# Altium schematic sheet Nixie Valve Clock.SchDoc
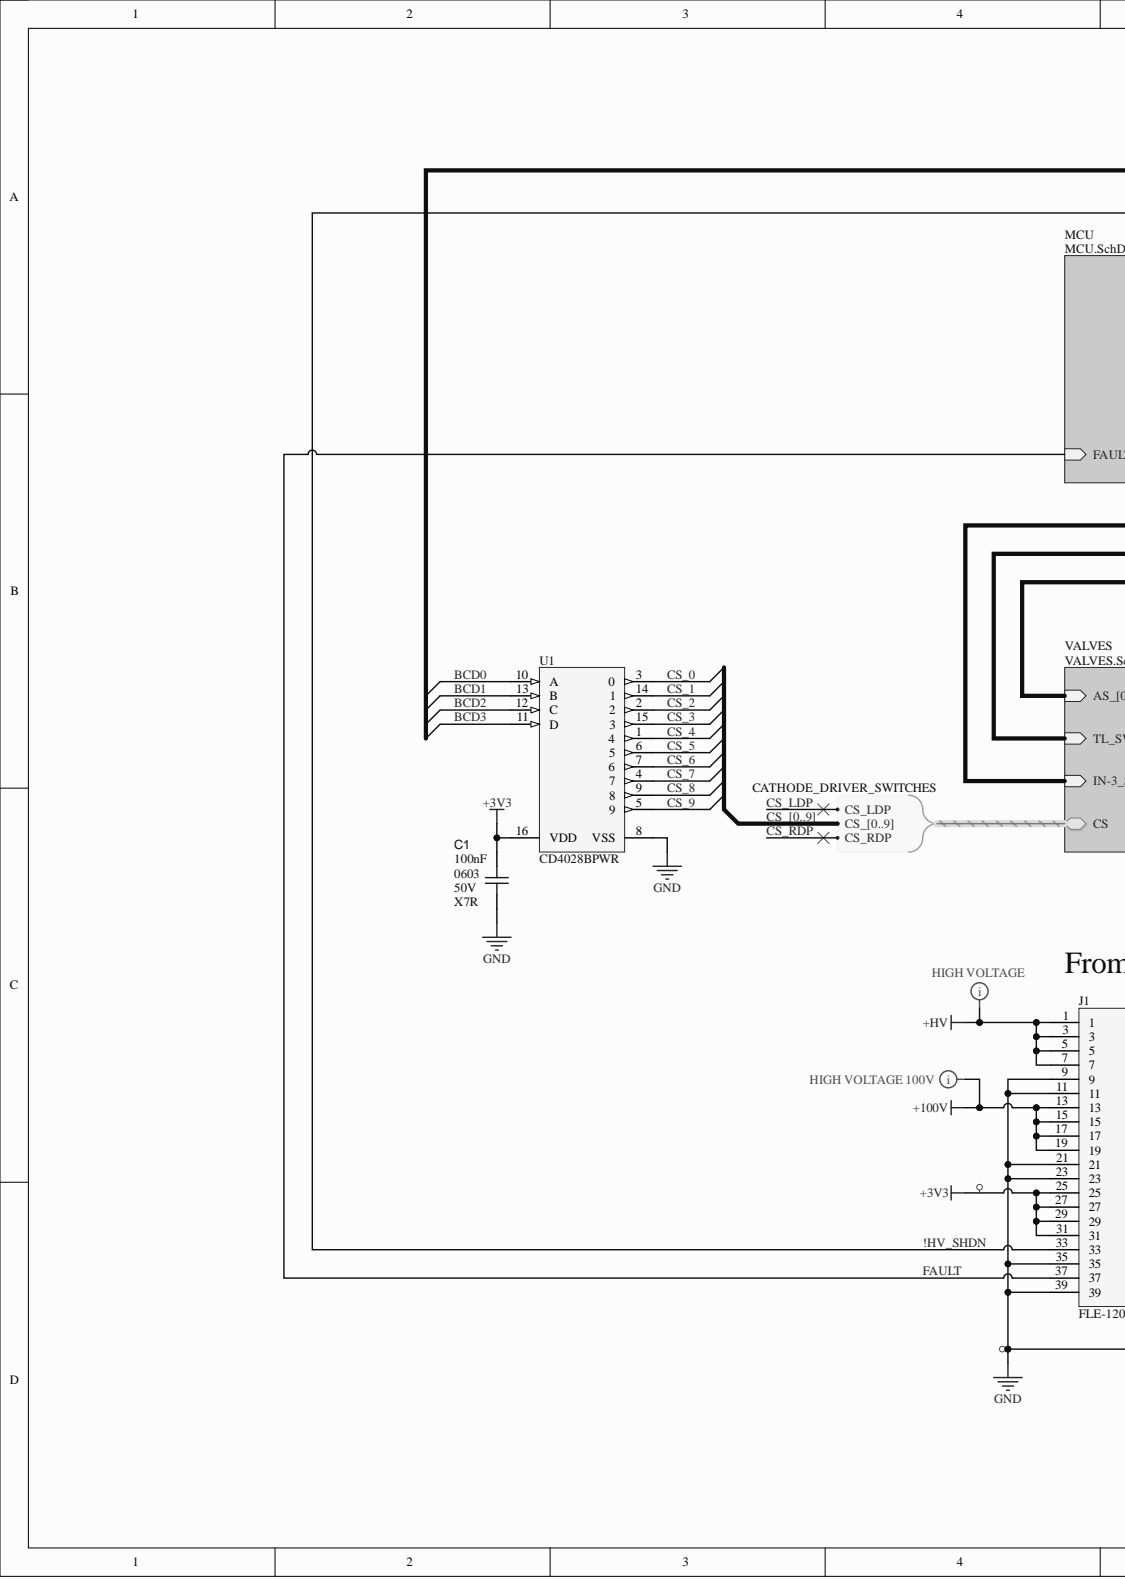
[diagram p0: Nixie Valve Clock.SchDoc, left]
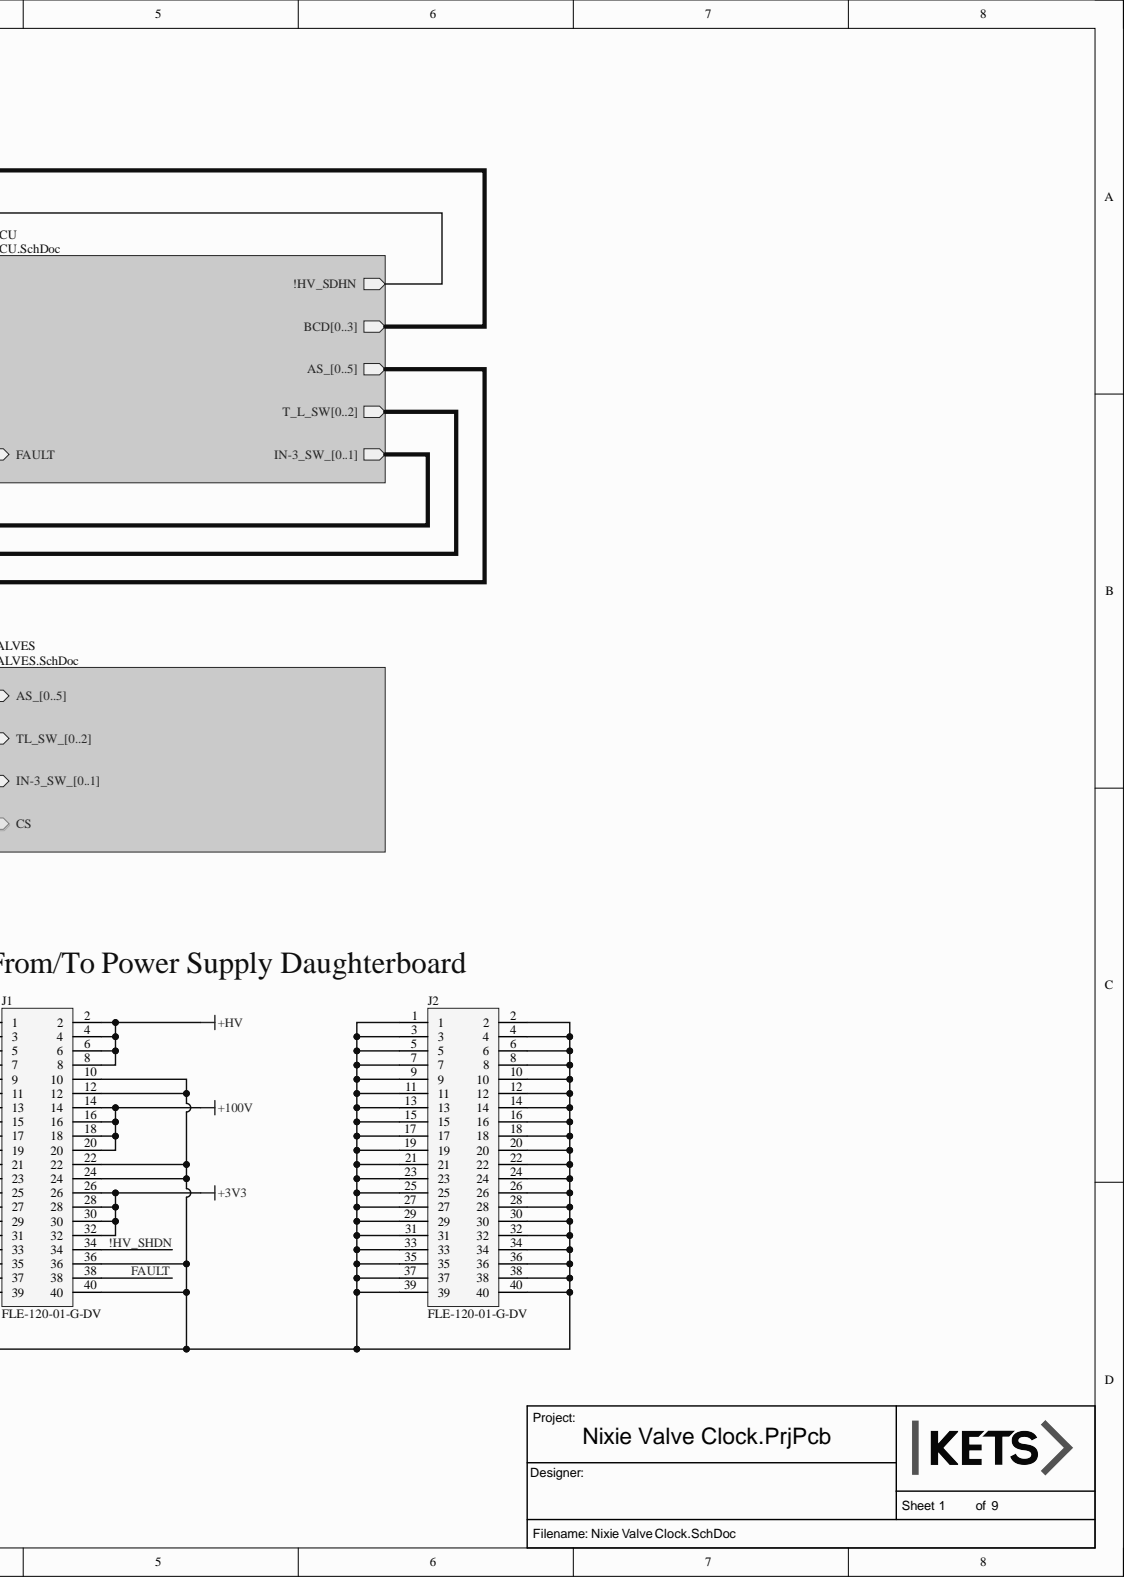
[diagram p1: Nixie Valve Clock.SchDoc, right]

## Parts
C1  value 100nF  Case/Package: 0603  Dielectric: X7R  Rated DC Voltage (URdc): 50V  [CAPC1608X09N]  (hidden comment: CGA3E2X7R1H104K080AA)
J1  FLE-120-01-G-DV  [FLE-120-01-XX-DV]
J2  FLE-120-01-G-DV  [FLE-120-01-XX-DV]
U1  CD4028BPWR  [PW0016A_N]

## Nets
+3V3: C1.1 J1.25 J1.26 J1.27 J1.28 J1.29 J1.30 J1.31 J1.32 U1.16(VDD)
+100V: J1.13 J1.14 J1.15 J1.16 J1.17 J1.18 J1.19 J1.20
+HV: J1.1 J1.2 J1.3 J1.4 J1.5 J1.6 J1.7 J1.8
GND: C1.2 J1.9 J1.10 J1.11 J1.12 J1.21 J1.22 J1.23 J1.24 J1.35 J1.36 J1.39 J1.40 J2.1 J2.2 J2.3 J2.4 J2.5 J2.6 J2.7 J2.8 J2.9 J2.10 J2.11 J2.12 J2.13 J2.14 J2.15 J2.16 J2.17 J2.18 J2.19 J2.20 J2.21 J2.22 J2.23 J2.24 J2.25 J2.26 J2.27 J2.28 J2.29 J2.30 J2.31 J2.32 J2.33 J2.34 J2.35 J2.36 J2.37 J2.38 J2.39 J2.40 U1.8(VSS)
!HV_SHDN: J1.33 J1.34
BCD0: U1.10(A)  [also labelled ]
BCD1: U1.13(B)  [also labelled ]
BCD2: U1.12(C)  [also labelled ]
BCD3: U1.11(D)  [also labelled ]
CS_0: U1.3  [also labelled CS_]
CS_1: U1.14  [also labelled CS_]
CS_2: U1.2  [also labelled CS_]
CS_3: U1.15  [also labelled CS_]
CS_4: U1.1  [also labelled CS_]
CS_5: U1.6  [also labelled CS_]
CS_6: U1.7  [also labelled CS_]
CS_7: U1.4  [also labelled CS_]
CS_8: U1.9  [also labelled CS_]
CS_9: U1.5  [also labelled CS_]
FAULT: J1.37 J1.38
AS_0:
AS_1:
AS_2:
AS_3:
AS_4:
AS_5:
CS_LDP:
CS_RDP:
IN-3_SW_0:
IN-3_SW_1:
T_L_SW0:
T_L_SW1:
T_L_SW2:

## Library data of the parts
C1 = CMP-001-00101-1: Ceramic Capacitor, Multilayer, Ceramic, 50V, 10% +Tol, 10% -Tol, X7R, 15% TC, 0.1uF, Surface Mount, 0603; Multilayer: Yes; Reference Standard: AEC-Q200; Capacitor Type: Ceramic; Component Kind: Standard; Dielectric Material: Ceramic; Height: 0.8mm; JESD-609 Code: e3; Length: 1.6mm; Max Operating Temperature: 125°C; Min Operating Temperature: -55°C; Mounting Technology: Surface Mount; Package Shape: Rectangular; Package Style: SMTMeter; Packaging: Tape and Reel; Pins: 2; Reach SVHC Compliant: Yes; RoHS Compliant: Yes; Temperature Characteristics Code: X7R; Terminal Finish: Nickel; Termination: Wraparound; Tolerance: 10%; Tolerance  (fill in as +-): 10%; Tolerance (fill in as +-): 10%; Voltage Rating: 50V; Width: 0.8mm; Library Name: KETS Quantum Security
J1 J2 = CMP-073-000000-3: PCB Receptacle, Board-to-Board, Wire-to-Board, 1.27 mm, 2 Rows, 40 Contacts, Surface Mount; Manufacturer Lifecycle Status: PRODUCTION; Connector Type: Receptacle, Socket; Contact Resistance: 15mΩ; Current Rating: 1.75A; MF: Samtec; Number of Contacts: 40; Pitch: 1.27mm; Availability: Unavailable; Color: Black; Component Kind: Standard; Component Type: Standard; Contact Material: Bronze; Contact Plating: Gold; Depth: 3.33mm; Design Item ID: FLE-120-01-XX-DV; Gender: Receptacle; Housing Color: Black; Housing Material: Polymer; Lead Free: Lead Free; Lead Pitch: 1.27mm; Length: 25.51mm; Lifecycle Status: Production; Max Current Rating: 1.75A; Max Operating Temperature: 125°C; Min Operating Temperature: -55°C; Mounting Technology: Surface Mount; MP: FLE-120-01-XX-DV; Number of Positions: 40; Number of Rows: 2; Orientation: Straight; Package: None; Packaging: Bulk; Pin Count: 40; Pins: 40; Price: None; Radiation Hardening: No; REACH SVHC: No; RoHS: Compliant; Row Spacing: 1.27mm; Source: FLE-120-01-XX-DV.SchLib; Termination: Solder; Library Name: KETS Quantum Security
U1 = CMP-00024-00008-1: CMOS BCD-to-Decimal or Binary-to-Octal Decoders/Drivers 16-TSSOP -55 to 125; Manufacturer Lifecycle Status: ACTIVE; Bandwidth: 8MHz; High Level Output Current: 6.8mA; Independent Circuits: 1; Logic Function: Decoder, Demultiplexer; Max Supply Voltage: 18V; Min Supply Voltage: 3V; Number of Bits: 10; Number of Input Lines: 4; Polarity: Non-Inverting; Propagation Delay: 160ns; Quiescent Current: 100µA; Supply Type: Dual, Single; Turn-On Delay Time: 350ns; Case/Package: TSSOP; Component Kind: Standard; Contact Plating: Gold; Height: 1.2mm; Lead Free: Lead Free; Length: 5mm; Lifecycle Status: Production; Low Level Output Current: 6.8mA; Max Operating Temperature: 125°C; Min Operating Temperature: -55°C; Mounting Technology: Surface Mount; Number of Channels: 1; Number of Elements: 1; Number of Inputs: 4; Number of Output Lines: 10; Number of Outputs: 10; Pins: 16; RoHS: Compliant; RoHS Compliant: Yes; Thickness: 1mm; Weight: 61.887009mg; Width: 4.4mm; Library Name: KETS Quantum Security
